# Altium schematic sheet FPGA_POWER_AND_CONFIGURATION.SchDoc
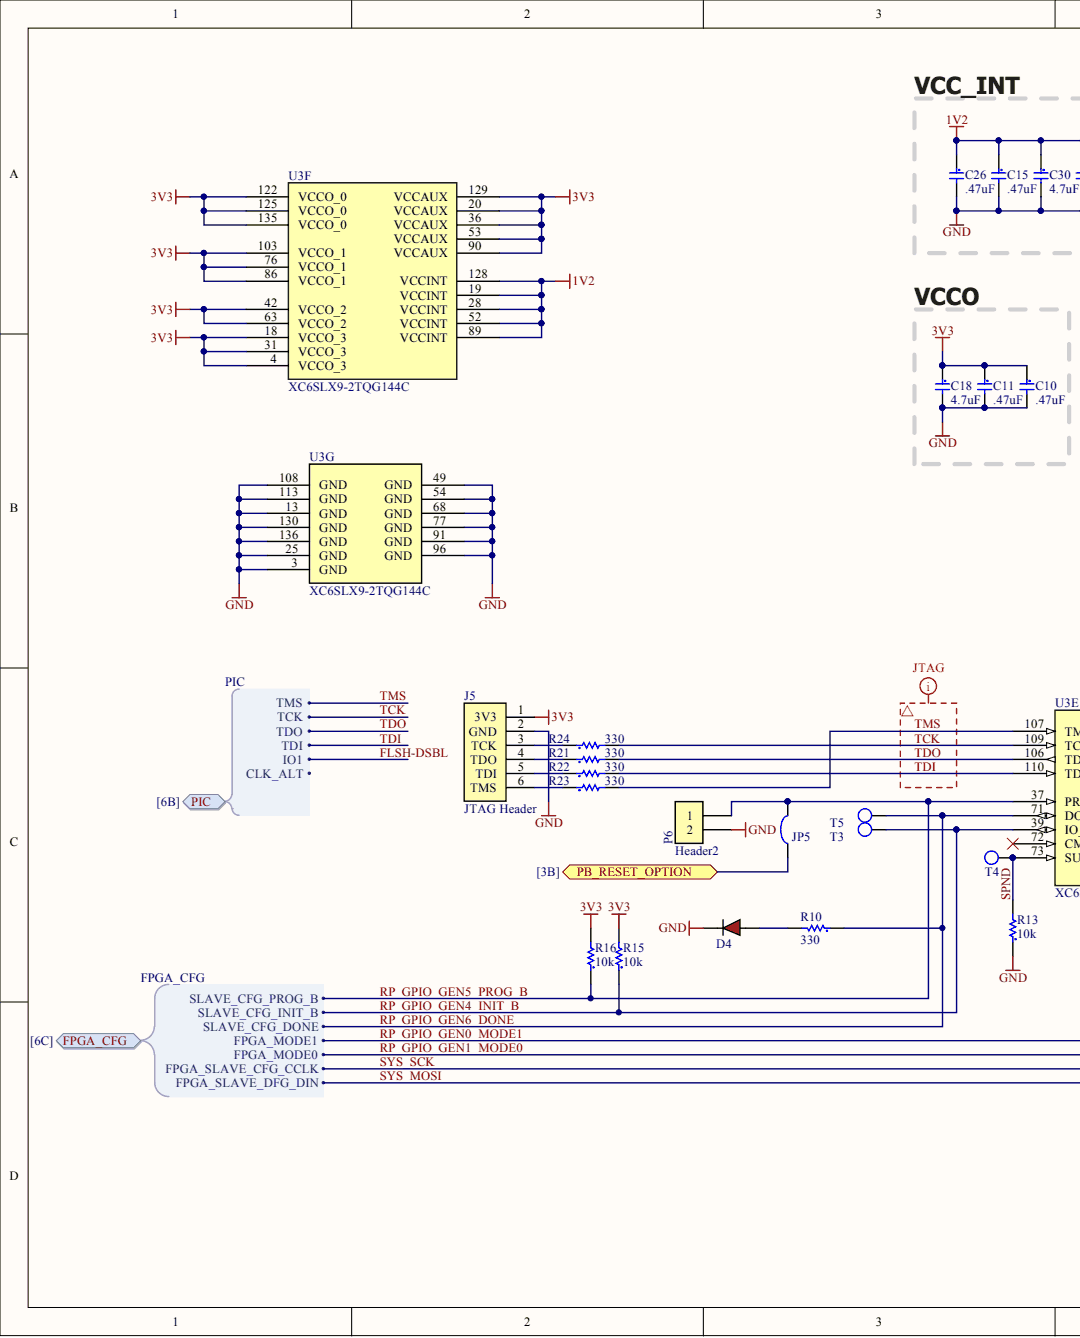
[diagram p0: FPGA_POWER_AND_CONFIGURATION.SchDoc, left]
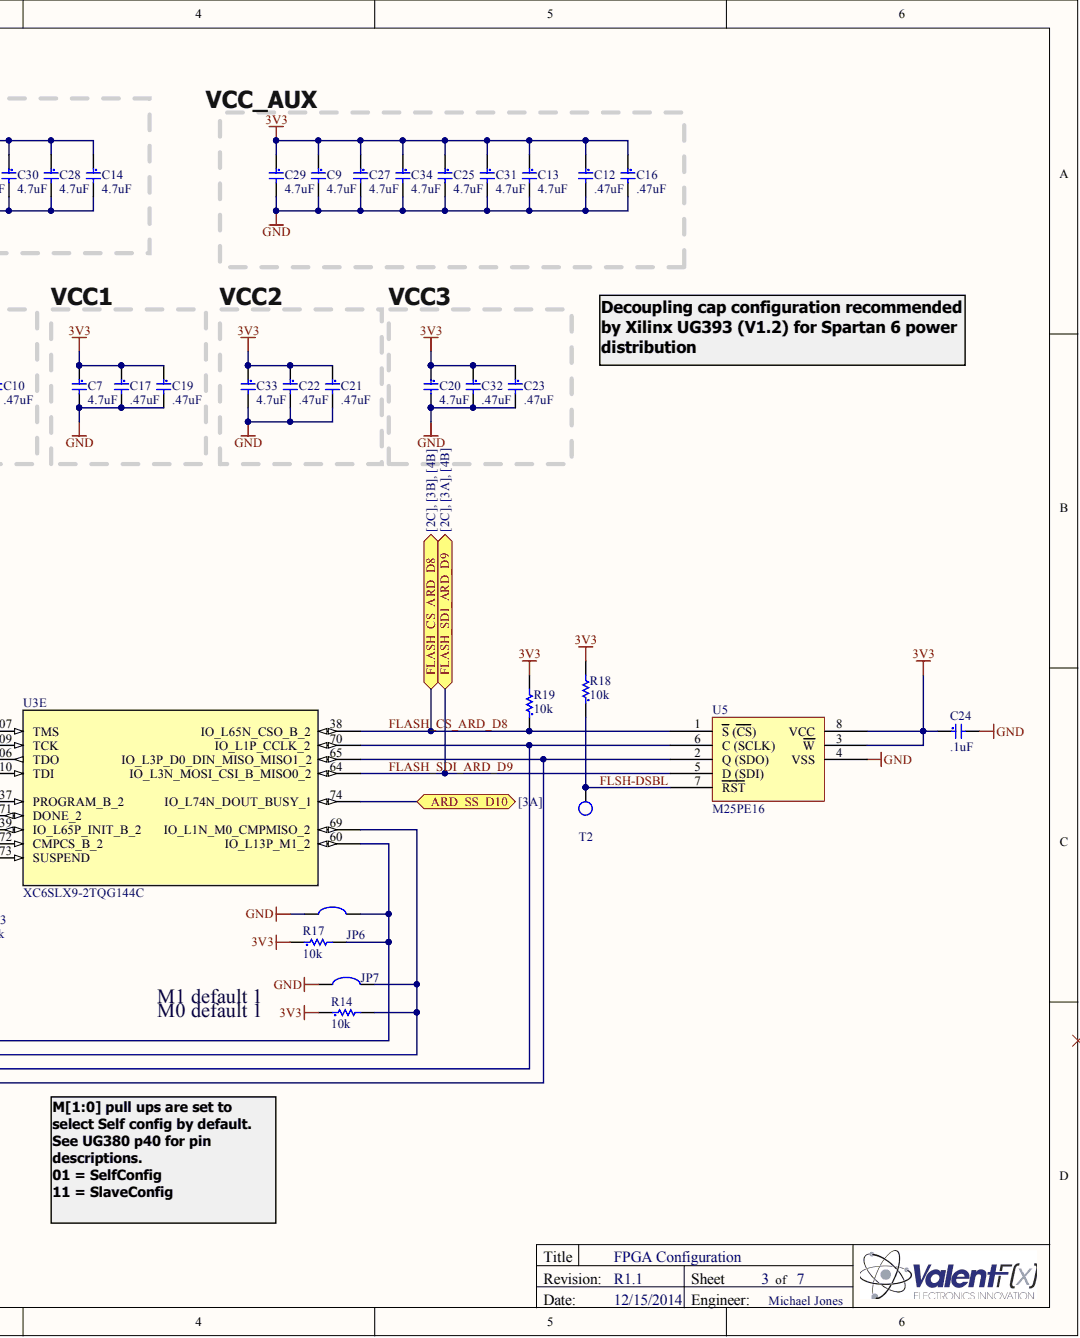
[diagram p1: FPGA_POWER_AND_CONFIGURATION.SchDoc, right]

## Parts
C7  4.7uF  [C0603 - Marked]
C9  4.7uF  [C0603 - Marked]
C10  .47uF  [C0603]
C11  .47uF  [C0603]
C12  .47uF  [C0603]
C13  4.7uF  [C0603 - Marked]
C14  4.7uF  [C0603 - Marked]
C15  .47uF  [C0603]
C16  .47uF  [C0603]
C17  .47uF  [C0603]
C18  4.7uF  [C0603 - Marked]
C19  .47uF  [C0603]
C20  4.7uF  [C0603 - Marked]
C21  .47uF  [C0603]
C22  .47uF  [C0603]
C23  .47uF  [C0603]
C24  .1uF  [c0603]
C25  4.7uF  [C0603 - Marked]
C26  .47uF  [C0603]
C27  4.7uF  [C0603 - Marked]
C28  4.7uF  [C0603 - Marked]
C29  4.7uF  [C0603 - Marked]
C30  4.7uF  [C0603 - Marked]
C31  4.7uF  [C0603 - Marked]
C32  .47uF  [C0603]
C33  4.7uF  [C0603 - Marked]
C34  4.7uF  [C0603 - Marked]
D4  598-8010-107F  [LED_0603 - RED]  (value not drawn)
J5  JTAG Header  [JTAG - Digilent HS1]  (no BOM)
JP5  Solder Jumper  [SOLDER_JUMPER]  (value not drawn)  (no BOM)
JP6  Solder Jumper  [SOLDER_JUMPER]  (value not drawn)  (no BOM)
JP7  Solder Jumper  [SOLDER_JUMPER]  (value not drawn)  (no BOM)
P6  Header2  [HEADER_2_40_100 THRU SIP]  (no BOM)
R10  330  [R0603]
R13  10k  [R0603]
R14  10k  [R0603]
R15  10k  [R0603]
R16  10k  [R0603]
R17  10k  [R0603]
R18  10k  [R0603]
R19  10k  [R0603]
R21  330  [R0603]
R22  330  [R0603]
R23  330  [R0603]
R24  330  [R0603]
T2  Test Point  [Tp 25-40]  (value not drawn)  (no BOM)
T3  Test Point  [Tp 25-40]  (value not drawn)  (no BOM)
T4  Test Point  [Tp 25-40]  (value not drawn)  (no BOM)
T5  Test Point  [Tp 25-40]  (value not drawn)  (no BOM)
U3  XC6SLX9-2TQG144C  [TQ144_L]  (parts E,F,G)
U5  M25PE16  [SOIC127P830X200-8L]

## Ports
ARD_SS_D10, FLASH_CS_ARD_D8, FLASH_SDI_ARD_D9, FPGA_CFG, FPGA_CFG.FPGA_MODE0, FPGA_CFG.FPGA_MODE1, FPGA_CFG.FPGA_SLAVE_CFG_CCLK, FPGA_CFG.FPGA_SLAVE_DFG_DIN, FPGA_CFG.SLAVE_CFG_DONE, FPGA_CFG.SLAVE_CFG_INIT_B, FPGA_CFG.SLAVE_CFG_PROG_B, PB_RESET_OPTION, PIC, PIC.CLK_ALT, PIC.IO1, PIC.TCK, PIC.TDI, PIC.TDO, PIC.TMS

## Nets
1V2: C14.1 C15.1 C26.1 C28.1 C30.1 U3.19(VCCINT) U3.28(VCCINT) U3.52(VCCINT) U3.89(VCCINT) U3.128(VCCINT)
3V3: C7.1 C9.1 C10.1 C11.1 C12.1 C13.1 C16.1 C17.1 C18.1 C19.1 C20.1 C21.1 C22.1 C23.1 C24.1 C25.1 C27.1 C29.1 C31.1 C32.1 C33.1 C34.1 J5.1(3V3) R14.1 R15.2 R16.2 R17.1 R18.2 R19.2 U3.4(VCCO_3) U3.18(VCCO_3) U3.20(VCCAUX) U3.31(VCCO_3) U3.36(VCCAUX) U3.42(VCCO_2) U3.53(VCCAUX) U3.63(VCCO_2) U3.76(VCCO_1) U3.86(VCCO_1) U3.90(VCCAUX) U3.103(VCCO_1) U3.122(VCCO_0) U3.125(VCCO_0) U3.129(VCCAUX) U3.135(VCCO_0) U5.3(W) U5.8(VCC)
GND: C7.2 C9.2 C10.2 C11.2 C12.2 C13.2 C14.2 C15.2 C16.2 C17.2 C18.2 C19.2 C20.2 C21.2 C22.2 C23.2 C24.2 C25.2 C26.2 C27.2 C28.2 C29.2 C30.2 C31.2 C32.2 C33.2 C34.2 D4.C J5.2(GND) JP6.2 JP7.2 P6.2 R13.1 U3.3(GND) U3.13(GND) U3.25(GND) U3.49(GND) U3.54(GND) U3.68(GND) U3.77(GND) U3.91(GND) U3.96(GND) U3.108(GND) U3.113(GND) U3.130(GND) U3.136(GND) U5.4(VSS)
ARD_SS_D10: U3.74(IO_L74N_DOUT_BUSY_1) ; port ARD_SS_D10
FLASH_CS_ARD_D8: R19.1 U3.38(IO_L65N_CSO_B_2) U5.1(S_(CS)) ; port FLASH_CS_ARD_D8
FLASH_SDI_ARD_D9: U3.64(IO_L3N_MOSI_CSI_B_MISO0_2) U5.5(D_(SDI)) ; port FLASH_SDI_ARD_D9
FLSH-DSBL: R18.1 T2.1 U5.7(RST) ; port PIC
PB_RESET_OPTION: JP5.2 ; port PB_RESET_OPTION
RP_GPIO_GEN0_MODE1_: JP6.1 R17.2 U3.60(IO_L13P_M1_2) ; port FPGA_CFG
RP_GPIO_GEN1_MODE0: JP7.1 R14.2 U3.69(IO_L1N_M0_CMPMISO_2) ; port FPGA_CFG
RP_GPIO_GEN4_INIT_B: R15.1 T3.1 U3.39(IO_L65P_INIT_B_2) ; port FPGA_CFG
RP_GPIO_GEN5_PROG_B: JP5.1 P6.1 R16.1 U3.37(PROGRAM_B_2) ; port FPGA_CFG
RP_GPIO_GEN6_DONE: R10.1 T5.1 U3.71(DONE_2) ; port FPGA_CFG
SPND: R13.2 T4.1 U3.73(SUSPEND)
SYS_MOSI: U3.65(IO_L3P_D0_DIN_MISO_MISO1_2) U5.2(Q_(SDO)) ; port FPGA_CFG
SYS_SCK: U3.70(IO_L1P_CCLK_2) U5.6(C_(SCLK)) ; port FPGA_CFG
TCK: R24.2 U3.109(TCK) ; port PIC
TDI: R22.2 U3.110(TDI) ; port PIC
TDO: R21.2 U3.106(TDO) ; port PIC
TMS: R23.2 U3.107(TMS) ; port PIC
NetD4_A: D4.A R10.2
NetJ5_3: J5.3(TCK) R24.1
NetJ5_4: J5.4(TDO) R21.1
NetJ5_5: J5.5(TDI) R22.1
NetJ5_6: J5.6(TMS) R23.1
N00139:
N00140: ; port PIC

Not connected: U3 72(CMPCS_B_2)

## Library data of the parts
C7 C9 C13 C14 C18 C20 C25 C27-C31 C33 C34 = C0603 - GRM188R60J475KE19D: 4.7uF 6.3volts X5R 10% (MLCC) - SMD/SMT 0603; Manufacturer: Murata Electronics North America; Capacitance: 4.7µF; Voltage - Rated: 6.3V; Applications: General Purpose; Category: Capacitors; Component Kind: Standard; ComponentLink1Description: http://search.murata.co.jp/Ceramy/image/img/PDF/ENG/GRM188R60J475KE19.pdf; ComponentLink1URL: http://search.murata.co.jp/Ceramy/image/img/PDF/ENG/GRM188R60J475KE19.pdf; Features: -; Height - Seated (Max): -; Lead Spacing: -; Lead Style: -; Library Name: mCapacitors.SchLib; Manufacturer Part Number: GRM188R60J475KE19D; Mounting Type: Surface Mount, MLCC; Operating Temperature: -55°C ~ 85°C; Package / Case: 0603 (1608 Metric); Packaging: Cut Tape (CT); Pricing 1: 1=0.19, 10=0.132, 50=0.076, 100=0.0627, 250=0.05284, 500=0.04426, 1000=0.03633 (USD); Ratings: -; RoHS: RoHS Compliant; Size / Dimension: 0.063" L x 0.031" W (1.60mm x 0.80mm); Stock 1: 614848; Supplier 1: Digi-Key; Supplier Part Number 1: 490-3297-1-ND; Temperature Coefficient: X5R; Thickness (Max): 0.035" (0.90mm); Tolerance: ±10%; Value: 4.7uF
C10-C12 C15-C17 C19 C21-C23 C26 C32 = C0603 - GCM188R71E474KA64D: .47uF 25VDC X7R 10% SMD 0603 Paper T/R CERAMIC; Manufacturer: Murata Electronics North America; Capacitance: 0.47µF; Voltage - Rated: 25V; Applications: Automotive; Category: Capacitors; Component Kind: Standard; ComponentLink1Description: http://search.murata.co.jp/Ceramy/image/img/PDF/ENG/GCM188R71E474KA64.pdf; ComponentLink1URL: http://search.murata.co.jp/Ceramy/image/img/PDF/ENG/GCM188R71E474KA64.pdf; Features: -; Height - Seated (Max): -; Lead Spacing: -; Lead Style: -; Library Name: mCapacitors.SchLib; Manufacturer Part Number: GCM188R71E474KA64D; Mounting Type: Surface Mount, MLCC; Operating Temperature: -55°C ~ 125°C; Package / Case: 0603 (1608 Metric); Packaging: Cut Tape (CT); Pricing 1: 1=0.26, 10=0.214, 50=0.1202, 100=0.1068, 250=0.08012, 500=0.07076, 1000=0.06275 (USD); Ratings: AEC-Q200; RoHS: RoHS Compliant; Size / Dimension: 0.063" L x 0.031" W (1.60mm x 0.80mm); Stock 1: 100000; Supplier 1: Digi-Key; Supplier Part Number 1: 490-4776-1-ND; Temperature Coefficient: X7R; Thickness (Max): 0.035" (0.90mm); Tolerance: ±10%; Value: .47uF
C24 = C0603 - CL10F104ZA8NNNC: CAP CER 0.1UF 25V Y5V 0603; Manufacturer: Samsung Electro-Mechanics America, Inc; Accept Alternative: Yes; Capacitance: 0.1µF; Temperature Coefficient: Y5V (F); Tolerance: -20%, +80%; Applications: General Purpose; Category: Capacitors; Component Kind: Standard; ComponentLink1Description: http://www.samsungsem.com/servlet/FileDownload?type=spec&file=CL10F104ZA8NNNC.pdf; ComponentLink1URL: http://www.samsungsem.com/servlet/FileDownload?type=spec&file=CL10F104ZA8NNNC.pdf; Features: -; Height - Seated (Max): -; Lead Spacing: -; Lead Style: -; Library Name: mCapacitors.SchLib; Manufacturer Part Number: CL10F104ZA8NNNC; Mounting Type: Surface Mount, MLCC; Operating Temperature: -30°C ~ 85°C; Package / Case: 0603 (1608 Metric); Packaging: Cut Tape (CT); Pricing 1: 1=0.1, 10=0.017, 25=0.012, 50=0.009, 100=0.0076, 250=0.00624, 500=0.00536, 1000=0.00417 (USD); Ratings: -; RoHS: RoHS Compliant; Size / Dimension: 0.063" L x 0.031" W (1.60mm x 0.80mm); Stock 1: 400907; Supplier 1: Digi-Key; Supplier Part Number 1: 1276-1011-1-ND; Thickness (Max): 0.035" (0.90mm); Value: .1uF; Voltage - Rated: 25V
D4 = LED0603 - 598-8010-107F: LED ALINGAP RED CLEAR 0603 SMD; Manufacturer: Dialight; Accept Replacement: YES - Water Clear; Current - Test: 20mA; Lens Style/Size: Rectangle with Flat Top, 1.1mm x 0.8mm; Millicandela Rating: 40mcd; Viewing Angle: 140°; Voltage - Forward (Vf) Typ: 2.2V; Wavelength - Dominant: 635nm; Category: Optoelectronics; Color: Red; Component Kind: Standard; ComponentLink1Description: http://media.digikey.com/pdf/Data%20Sheets/Dialight%20PDFs/598_Series_0603_Pkg.pdf; ComponentLink1URL: http://media.digikey.com/pdf/Data%20Sheets/Dialight%20PDFs/598_Series_0603_Pkg.pdf; Height: 0.70mm; Lens Type: Clear; Library Name: mDiode.SchLib; Luminous Flux @ Current - Test: -; Manufacturer Part Number: 598-8010-107F; Mounting Type: Surface Mount; Package / Case: 0603 (1608 Metric); Packaging: Digi-Reel®; Pricing 1: 1=0.45, 10=0.33, 25=0.264, 100=0.1749, 250=0.1452, 500=0.1056, 1000=0.0825 (USD); RoHS: RoHS Compliant; Size / Dimension: 1.60mm L x 0.80mm W; Stock 1: 37578; Supplier 1: Digi-Key; Supplier Part Number 1: 350-2029-6-ND; Wavelength - Peak: -
J5 = JTAG - Digilent HS1: DIGILENT - 410-205 - PROGRAMMING CABLE, JTAG HS1, USB2; Manufacturer: Samtec; Manufacturer Part Number: TSW-106-08-G-S-RA; Connector Type: Header, Unshrouded; Lead Time: 0 weeks; MSL (Moisture Sensitivity Level): N/R; Number of Positions: 6; Number of Positions Loaded: All; Peak Solder Temperature: 260 deg C; Pitch: 0.100" (2.54mm); Category: Connectors, Interconnects; China ROHS Cadmium (X -> above / O -> below threshold): O; China ROHS Hex Chromium (X -> above / O -> below threshold): O; China ROHS Lead (X -> above / O -> below threshold): O; China ROHS Mercury (X -> above / O -> below threshold): O; China ROHS PBB (X -> above / O -> below threshold): O; China ROHS PBDE (X -> above / O -> below threshold): O; Color: Black; ComponentLink1Description: http://components.arrow.com/partservice/dataview/altium/2677508S3639200N1004?apiKey=aa438727d59eed98c4bed826d8d949c84cb5e685b92bf87346a0226bd2658797; ComponentLink1URL: http://components.arrow.com/partservice/dataview/altium/2677508S3639200N1004?apiKey=aa438727d59eed98c4bed826d8d949c84cb5e685b92bf87346a0226bd2658797; ComponentLink2Description: http://www.samtec.com/documents/webfiles/cpdf/TSW-XXX-XX-XXX-X-XX-XXX-MKT.pdf; ComponentLink2URL: http://www.samtec.com/documents/webfiles/cpdf/TSW-XXX-XX-XXX-X-XX-XXX-MKT.pdf; Contact Finish: Gold; Contact Finish Thickness: 10µin (0.25µm); Contact Mating Length: 0.230" (5.84mm); Contact Type: Male Pin; EU_ROHS_PROD_EXEMPTION_BY_SUPP: N/R; Fastening Type: -; Features: -; Library Name: mConnector.SchLib; Mounting Type: Through Hole; MSL Level Max: N/R; MSL Level Min: N/R; Number of Rows: 1; Package Quantity: 1; Packaging: Bulk; Pricing 1: 0=0.2649 (USD); Pricing 2: 1=0.94, 10=0.841, 100=0.6926, 500=0.5475, 1000=0.46999, 5000=0.42877, 10000=0.41228 (USD); RoHS: CHINA ROHS: Compliant / EU ROHS: Compliant; Row Spacing: -; Stock 1: 0; Stock 2: 0; Supplier 1: Arrow; Supplier 2: Digi-Key; Supplier Part Number 1: 2677508S3639200N1004; Supplier Part Number 2: SAM1038-06-ND; Termination: Solder; Termination Finish: Au/Ni; Value: JTAG Header
JP5-JP7 = Solder Jumper: Solder/Configuration Jumper; Library Name: mConnector.SchLib; Manufacturer: n/a; Manufacturer Part Number: n/a; ROHS Certificate: n/a; Source: n/a; Source Part Number: n/a
P6 = Header2: Header 2 pin; Library Name: mConnector.SchLib
R10 R21-R24 = R0603 - ERJ-3GEYJ331V: Thick Film Resistors - SMD 0603 330ohms 5% Tol; Manufacturer: Panasonic; Power Rating: 100 mW; Temperature Coefficient: 200 PPM / C; Voltage Rating: 75 V; Case Code - in: 0603; Case Code - mm: 1608; Category: Thick Film Resistors - SMD; Component Kind: Standard; ComponentLink1Description: http://www.mouser.com/ds/2/315/AOA0000CE1-37422.pdf; ComponentLink1URL: http://www.mouser.com/ds/2/315/AOA0000CE1-37422.pdf; Dimensions: 0.8 mm W x 1.6 mm L x 0.45 mm H; Library Name: mResistors.SchLib; Manufacturer Part Number: ERJ-3GEYJ331V; Minimum Order Quantity: 1; Operating Temperature Range: - 55 C to + 155 C; Package / Case: 0603 (1608 metric); Packaging: Reel; Pricing 1: 1=0.108, 10=0.012, 100=0.005, 1000=0.004, 5000=0.003 (AUD); Product: Thick Film Resistors SMD; Reeling: True; Resistance: 330 Ohms; RoHS: RoHS Compliant By Exemption; RoHS - Mouser: E; Series: ERJ; Standard Pack Qty: 5000; Stock 1: 131470; Supplier 1: Mouser; Supplier Part Number 1: 667-ERJ-3GEYJ331V; Termination Style: SMD/SMT; Tolerance: 5 %; Value: 330
R13-R19 = R0603 - CRCW060310K0FKEA: 10k Thick Film Resistors - SMD 1/10watt 10Kohms 1%; Manufacturer: Vishay Dale; Power (Watts): 0.1W, 1/10W; Temperature Coefficient: ±100ppm/°C; Category: Resistors; Component Kind: Standard; ComponentLink1Description: http://www.vishay.com/doc?20035; ComponentLink1URL: http://www.vishay.com/doc?20035; Composition: Thick Film; Features: -; Height: 0.020" (0.50mm); Library Name: mResistors.SchLib; Manufacturer Part Number: CRCW060310K0FKEA; Number of Terminations: 2; Package / Case: 0603 (1608 Metric); Packaging: Cut Tape (CT); Pricing 1: 10=0.081, 50=0.0436, 200=0.0251, 1000=0.01711 (USD); Resistance (Ohms): 10K; RoHS: RoHS Compliant; Size / Dimension: 0.061" L x 0.033" W (1.55mm x 0.85mm); Stock 1: 997856; Supplier 1: Digi-Key; Supplier Part Number 1: 541-10.0KHCT-ND; Tolerance: ±1%; Value: 10k
T2-T5 = Test Point 2: Test Point Smaller Symbol; Library Name: mConnector.SchLib
U3 = FPGA - XC6SLX9-2TQG144C: IC FPAG SPARTAN 6 9K 144TQFP; Manufacturer: Xilinx Inc; Number of I /O: 102; Number of LABs/CLBs: 715; Number of Logic Elements/Cells: 9152; Total RAM Bits: 589824; Voltage - Supply: 1.14 V ~ 1.26 V; Category: Integrated Circuits (ICs); Component Kind: Standard; ComponentLink1Description: http://www.xilinx.com/support/documentation/data_sheets/ds160.pdf; ComponentLink1URL: http://www.xilinx.com/support/documentation/data_sheets/ds160.pdf; Library Name: mIC.SchLib; Manufacturer Part Number: XC6SLX9-2TQG144C; Mounting Type: Surface Mount; Number of Gates: -; Operating Temperature: 0°C ~ 85°C; Package / Case: 144-LQFP; Pricing 1: 1=12.80,100=11.05,1000=9.59 (USD); RoHS: RoHS Compliant; Stock 1: 994; Supplier 1: America II Electronics; Supplier 2: Digi-Key; Supplier Device Package: 144-TQFP (20x20); Supplier Part Number 1: 122-1745-ND; Value: XC6SLX9-2TQG144C
U5 = M25PE16-VMW6TG: IC FLASH 16MBIT 75MHZ 8SOIC; Manufacturer: Numonyx/ST Micro; Interface: SPI, 3-Wire Serial; Memory Size: 16M (2M x 8); Voltage - Supply: 2.7 V ~ 3.6 V; Category: Integrated Circuits (ICs); Component Kind: Standard; ComponentLink1Description: http://www.numonyx.com/Documents/Datasheets/M25PE16.pdf; ComponentLink1URL: http://www.numonyx.com/Documents/Datasheets/M25PE16.pdf; Format - Memory: FLASH; Library Name: ICs.SchLib; Manufacturer Part Number: M25PE16-VMW6TG; Memory Type: FLASH; Operating Temperature: -40°C ~ 85°C; Package / Case: 8-SOIC (0.200", 5.30mm Width); Packaging: Cut Tape (CT); Pricing 1: 1=2.31, 10=1.98, 25=1.782, 100=1.617, 250=1.452, 500=1.254 (USD); RoHS: RoHS Compliant; Speed: 75MHz; Stock 1: 866; Supplier 1: Digi-Key; Supplier Part Number 1: M25PE16-VMW6TGCT-ND; Value: M25PE16
